# Altium schematic sheet Schematics.SchDoc
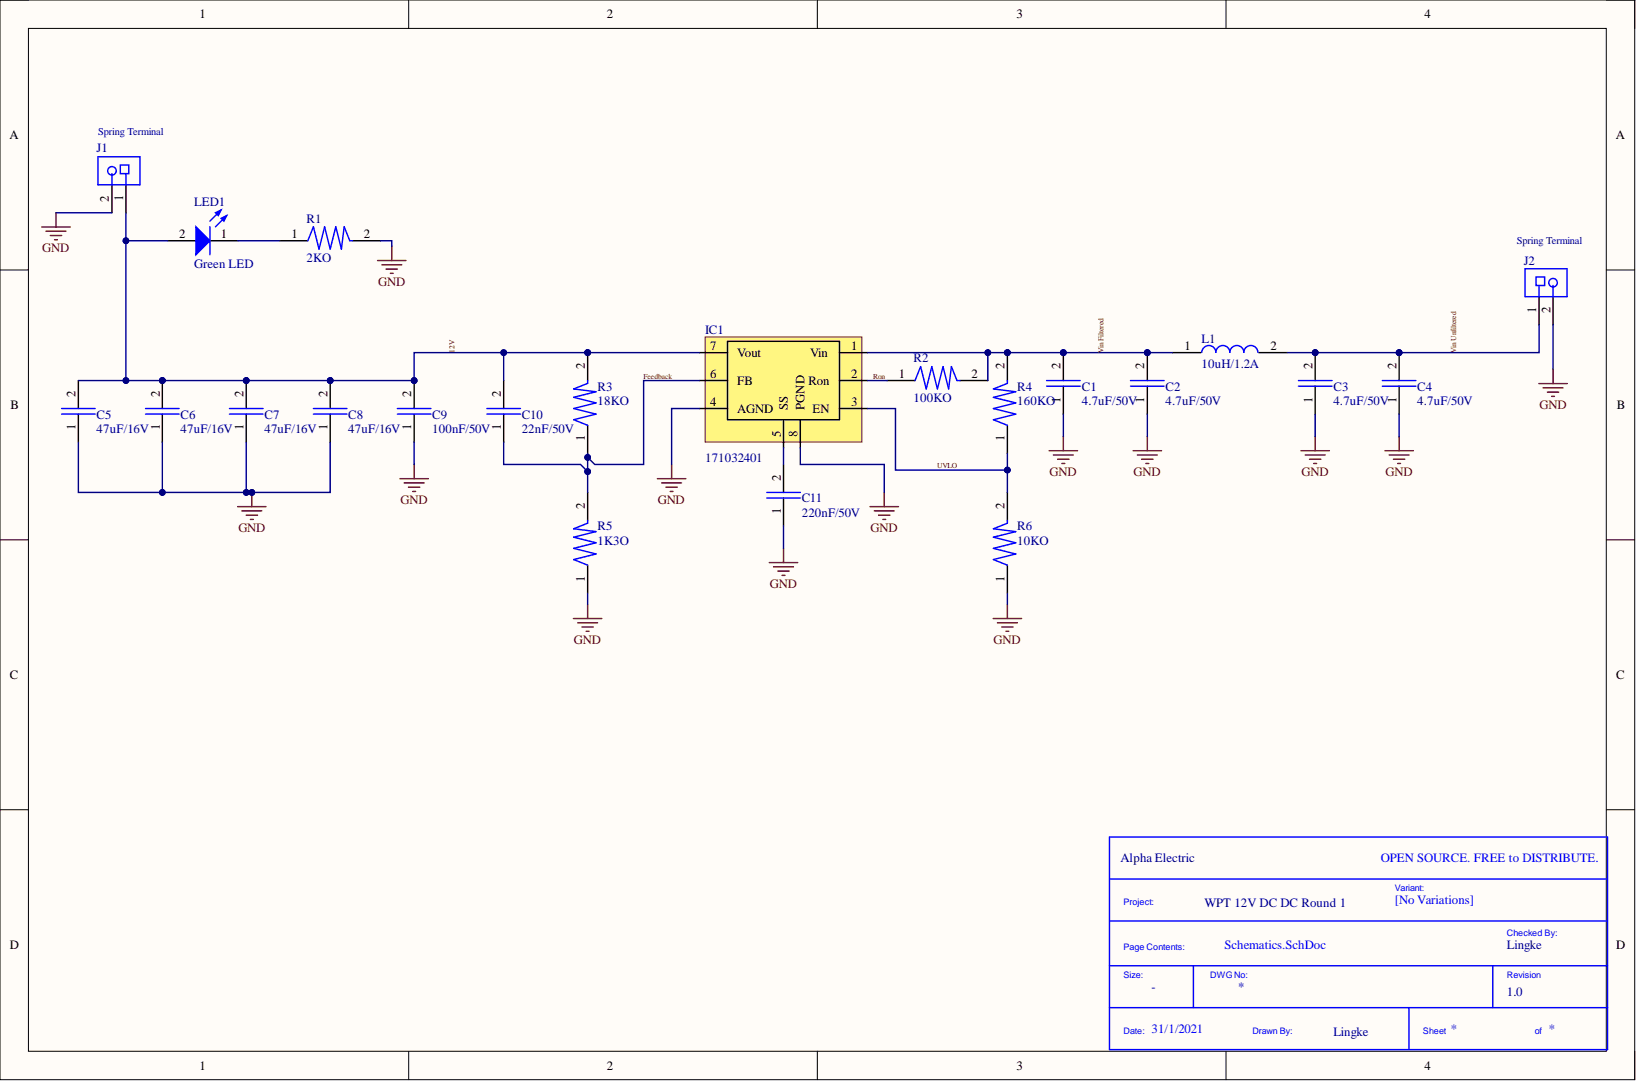
[diagram p0: Schematics.SchDoc]

## Parts
*  Checked By: Lingke  Company: Alpha Electric  Project Name: WPT 12V DC DC Round 1  Revision: 1.0  (hidden comment: Title_Block)  (no BOM)
C1  4.7uF/50V  [CAPC3225X280N]
C2  4.7uF/50V  [CAPC3225X280N]
C3  4.7uF/50V  [CAPC3225X280N]
C4  4.7uF/50V  [CAPC3225X280N]
C5  47uF/16V  [CAPC3225X280N]
C6  47uF/16V  [CAPC3225X280N]
C7  47uF/16V  [CAPC3225X280N]
C8  47uF/16V  [CAPC3225X280N]
C9  100nF/50V  [CAPC3216X90N]
C10  22nF/50V  [CAPC2012X90N]
C11  220nF/50V  [CAPC2012X90N]
IC1  171032401  [7 PIN PFM]
J1  Spring Terminal  [691411510002]
J2  Spring Terminal  [691411510002]
L1  10uH/1.2A  [WE-MAPI_3020]
LED1  Green LED  Color: Green  [0805_A]
R1  2KO  [FP-CRCW0805-e3-MFG]
R2  100KO  [FP-CRCW0805-e3-MFG]
R3  18KO  [FP-CRCW0805-e3-MFG]
R4  160KO  [FP-CRCW0805-e3-MFG]
R5  1K3O  [FP-CRCW0805-e3-MFG]
R6  10KO  [FP-CRCW0805-e3-MFG]

## Nets
GND: C1.1 C2.1 C3.1 C4.1 C5.1 C6.1 C7.1 C8.1 C9.1 C11.1 IC1.4(AGND) IC1.8(PGND) J1.2 J2.2 R1.2 R5.1 R6.1
12V: C5.2 C6.2 C7.2 C8.2 C9.2 C10.2 IC1.7(Vout) J1.1 LED1.2 R3.2
Feedback: C10.1 IC1.6(FB) R3.1 R5.2
Ron: IC1.2(Ron) R2.1
UVLO: IC1.3(EN) R4.1 R6.2
Vin Filtered: C1.2 C2.2 IC1.1(Vin) L1.1 R2.2 R4.2
Vin Unfiltered: C3.2 C4.2 J2.1 L1.2
NetC11_2: C11.2 IC1.5(SS)
NetLED1_1: LED1.1 R1.1

## Library data of the parts
* = Title_Block: Standard Title Block.; Library Name: Symbol Library.SchLib; Size: -; Drawn By: Lingke
C1-C4 = 885012209048: Capacitor 4.7uF/50V; Manufacturer_Name: Wurth Elektronik; Component Kind: Standard; Datasheet Link: https://katalog.we-online.com/pbs/datasheet/885012209048.pdf; Height: 2.8mm; Library Name: Symbol Library.SchLib; Manufacturer_Part_Number: 885012209048; Supplier 1: Mouser; Supplier Part Number 1: 710-885012209048
C5-C8 = EMK325ABJ476MMHP: Capacitor 47uF/16V; Component Kind: Standard; Library Name: Symbol Library.SchLib; Supplier 1: Mouser; Supplier Part Number 1: 963-EMK325ABJ476MMHP
C9 = 885012208087: Capacitor 100nF/50V; Manufacturer_Name: Wurth Elektronik; Component Kind: Standard; Datasheet Link: https://componentsearchengine.com//885012208087.pdf; Height: 0.9mm; Library Name: Symbol Library.SchLib; Manufacturer_Part_Number: 885012208087; Mouser2 Part Number: 710-885012208087; Mouser2 Price/Stock: https://www.mouser.com/Search/Refine.aspx?Keyword=710-885012208087; Supplier 1: Mouser; Supplier Part Number 1: 710-885012208087
C10 = 885012207094: Capacitor 22nF/50V; Manufacturer_Name: Wurth Elektronik; Component Kind: Standard; Datasheet Link: https://componentsearchengine.com//885012207094.pdf; Height: 0.9mm; Library Name: Symbol Library.SchLib; Manufacturer_Part_Number: 885012207094; Mouser2 Part Number: 710-885012207094; Mouser2 Price/Stock: https://www.mouser.com/Search/Refine.aspx?Keyword=710-885012207094; Supplier 1: Mouser; Supplier Part Number 1: 710-885012207094
C11 = 885012207100: Capacitor 220nF / 50V; Component Kind: Standard; Library Name: Symbol Library.SchLib; Supplier 1: Mouser; Supplier Part Number 1: 710-885012207100
IC1 = 171032401: MagI³C-VDRM Variable Step Down Regulator Module WE-VDRM , Ui=6-42V; Manufacturer: Wuerth Elektronik; Ui: 6-42 V; Component Kind: Standard; ComponentLink1Description: Manufacturer URL; ComponentLink1URL: http://www.we-online.com; ComponentLink2Description: Datasheet; ComponentLink2URL: http://katalog-live.we-online.de/pm/datasheet/171032401.pdf; Library Name: Symbol Library.SchLib; PackageReference: 7 PIN PFM; PartNumber: 171032401; Supplier 1: Mouser; Supplier Part Number 1: 710-171032401
J1 J2 = 691411510002: Serie 4115 - 5.08mm Screwless Horizontal Entry WR-TBL, 2 pin; Manufacturer: Wurth Elektronik; Pin Number: 2; Component Kind: Standard; Datasheet URL: http://katalog.we-online.de/em/datasheet/6914115100XX.pdf; Library Name: Symbol Library.SchLib; Manufacturer URL: http://www.we-online.de; Mounting Technology: THT; Operating Temperature: -30to120degC; Package Reference: 691411510002; Packaging: Box; Part Number: 691411510002; Pitch: 5.08mm; Supplier 1: Mouser; Supplier Part Number 1: 710-691411510002; Publisher: WurthElektronik
L1 = 74438336100: Inductor; Manufacturer_Name: Wurth Elektronik; Component Kind: Standard; Datasheet Link: http://katalog.we-online.de/pbs/datasheet/74438336100.pdf; Library Name: Symbol Library.SchLib; Manufacturer_Part_Number: 74438336100; Supplier 1: Mouser; Supplier Part Number 1: 710-74438336100
LED1 = 150080GS75000: SMD mono-color Chip LED, WL-SMCW, Green; Manufacturer: Wuerth Elektronik; Component Kind: Standard; ComponentLink1Description: Manufacturer URL; ComponentLink1URL: http://www.we-online.com; ComponentLink2Description: Datasheet; ComponentLink2URL: http://katalog.we-online.com/led/datasheet/150080GS75000.pdf; Library Name: Symbol Library.SchLib; PackageReference: 0805_A; PartNumber: 150080GS75000; Supplier 1: Mouser; Supplier Part Number 1: 710-150080GS75000
R1 = CRCW08052K00FKEB: RES Thick Film, 2kΩ, 1%, 0.125W, 100ppm/°C, 0805; Max Power Dissipation: 125mW; Resistance: 2kR; Voltage Rating: 150V; Case Code (Imperial): 0805; Case Code (Metric): 2012; Case/Package: 0805; Component Kind: Standard; Composition: Thick Film; Contact Plating: Tin; Features: Automotive AEC-Q200; Height: 0.02inch; Lead Free: Lead Free; Length: 0.079inch; Library Name: Symbol Library.SchLib; Max Operating Temperature: 155°C; Min Operating Temperature: -55°C; Number of Pins: 2; Number of Terminations: 2; Package Quantity: 10000; Packaging: Tape and Reel; Power Rating: 125mW; Radiation Hardening: No; Supplier 1: Mouser; Supplier Part Number 1: 71-CRCW08052K00FKEB; Temperature Coefficient: 100ppm/°C; Termination: SMD/SMT; Tolerance: 1%; Voltage Rating (DC): 150V; Weight: 0.000194oz; Width: 0.049inch
R2 = CRCW0805100KFKEB: Resistor 100K Ohm; Component Kind: Standard; Library Name: Symbol Library.SchLib; Number of Terminations: 2; Supplier 1: Mouser; Supplier Part Number 1: 71-CRCW0805100KFKEB
R3 = CRCW080518K0FKEA: Resistor 18K Ohm; Component Kind: Standard; Library Name: Symbol Library.SchLib; Number of Terminations: 2; Supplier 1: Mouser; Supplier Part Number 1: 71-CRCW080518K0FKEA
R4 = CR0805-FX-1603ELF: Resistor 160K Ohm; Component Kind: Standard; Library Name: Symbol Library.SchLib; Number of Terminations: 2; Supplier 1: Mouser; Supplier Part Number 1: 652-CR0805FX-1603ELF
R5 = CRCW08051K30FKEB: Resistor 1K3 Ohm; Component Kind: Standard; Library Name: Symbol Library.SchLib; Number of Terminations: 2; Supplier 1: Mouser; Supplier Part Number 1: 71-CRCW08051K30FKEB
R6 = CRCW080510K0FKEAC: Resistor 10K Ohm; Component Kind: Standard; Library Name: Symbol Library.SchLib; Number of Terminations: 2; Supplier 1: Mouser; Supplier Part Number 1: 71-CRCW0805-10K-E3
